# Altium schematic sheet Power.SchDoc(Power)
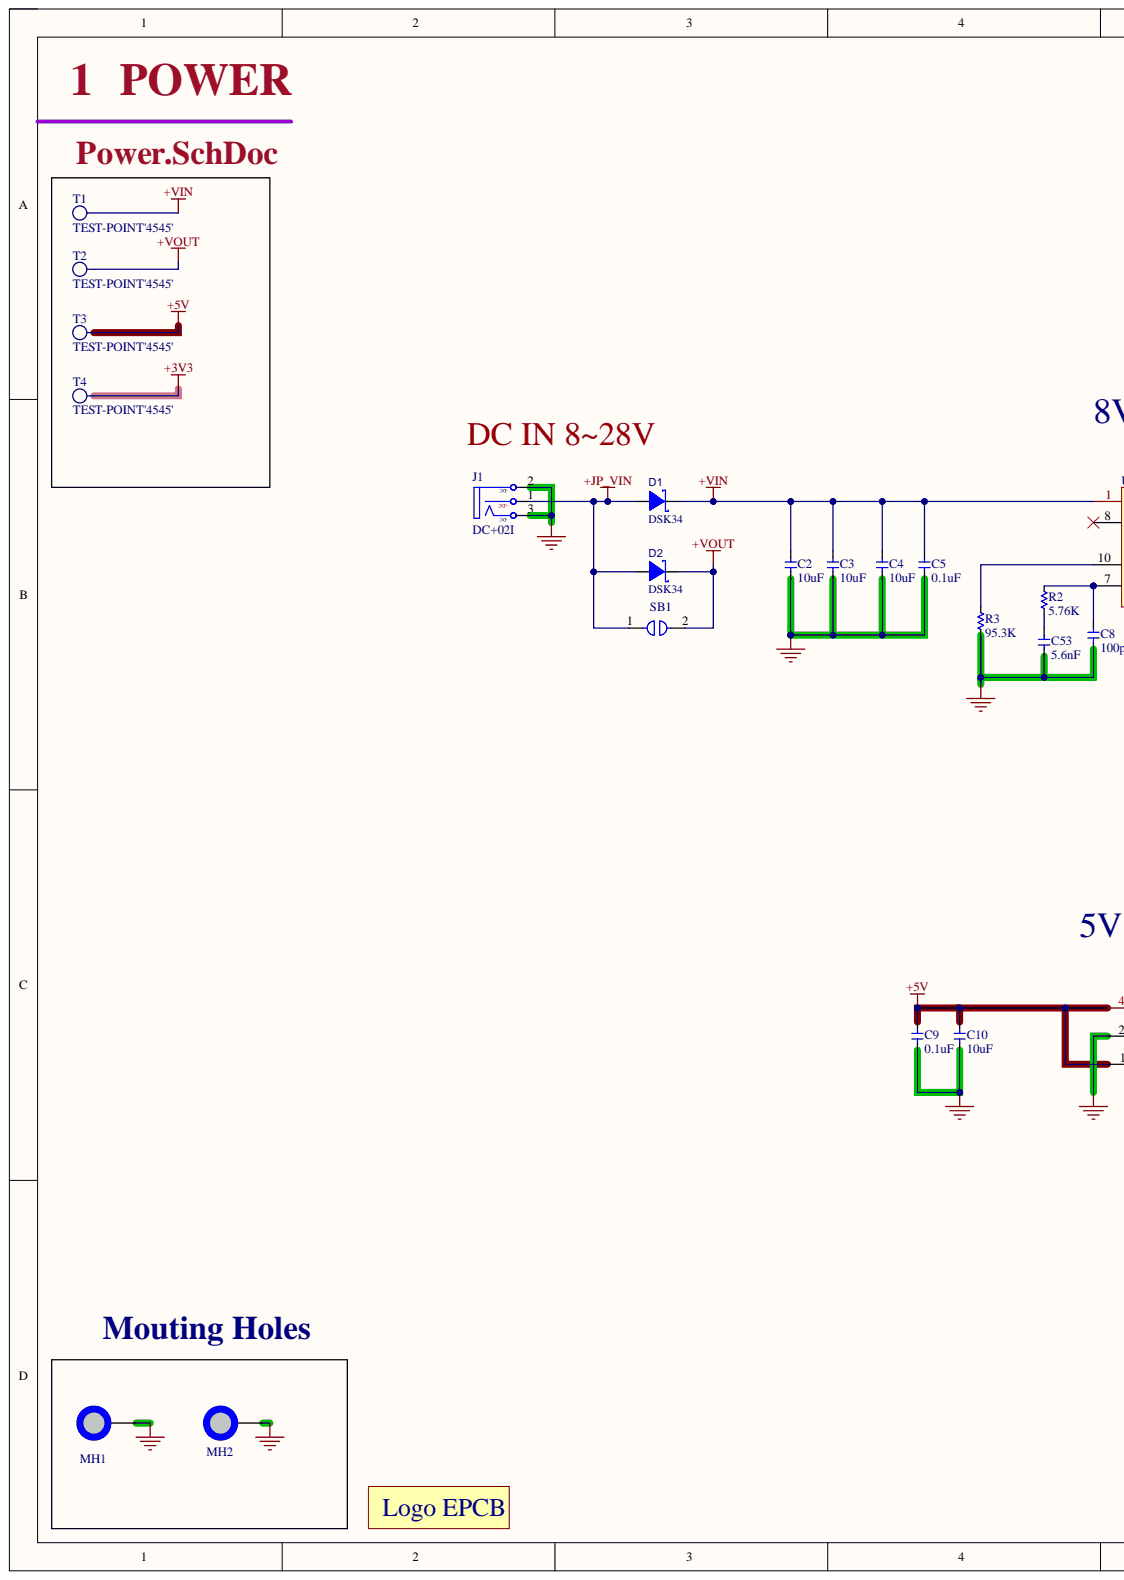
[diagram p0: Power.SchDoc, left]
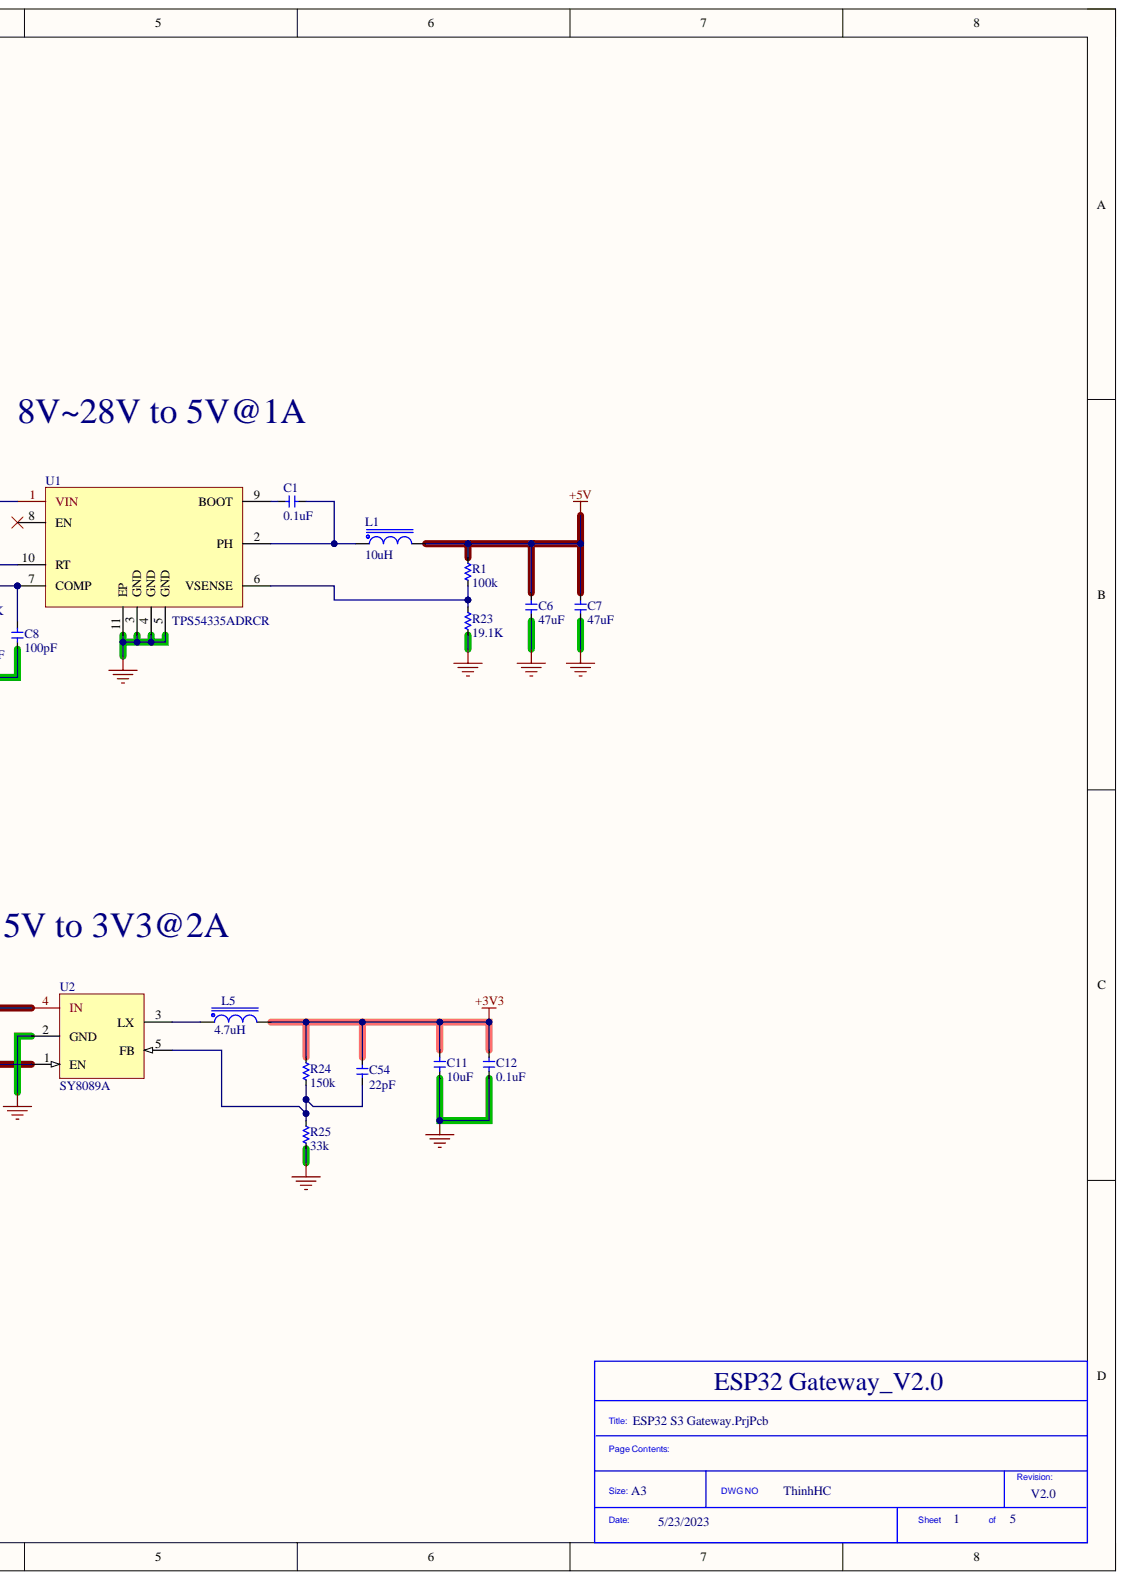
[diagram p1: Power.SchDoc, right]

## Parts
C1  0.1uF  [C_0402]
C2  10uF  [C_0805]
C3  10uF  [C_0805]
C4  10uF  [C_0805]
C5  0.1uF  [C-0603]
C6  47uF  [C-1210]
C7  47uF  [C-1210]
C8  100pF  [C_0402]
C9  0.1uF  [C_0402]
C10  10uF  [C_0603]
C11  10uF  [C_0603]
C12  0.1uF  [C_0402]
C53  5.6nF  [C_0402]
C54  22pF  [C_0402]
D1  DSK34  [SOD-123_L2.8-W1.8-LS3.7-RD]
D2  DSK34  [SOD-123_L2.8-W1.8-LS3.7-RD]
J1  DC+02I  [DC0]
L1  10uH  [IND-SMD_L4.4-W4.2]
L5  4.7uH  [IND-SMD_L4.4-W4.2]
LO1  LOGO EPCB  [LOGO EPCB]  (value not drawn)  (no BOM)
MH1  MH_6.0MMC_3.5MM  [MH_6.0MMD_3.5MM - Via]  (value not drawn)  (no BOM)
MH2  MH_6.0MMC_3.5MM  [MH_6.0MMD_3.5MM - Via]  (value not drawn)  (no BOM)
R1  100k  [R_0402]
R2  5.76K  [R_0402]
R3  95.3K  [R_0402]
R23  19.1K  [R_0402]
R24  150k  [R_0402]
R25  33k  [R_0402]
SB1  SOLDERJUMPER_1WAY_OFF  [SOLDERJUMPER_1WAY_OFF]  (value not drawn)  (no BOM)
T1  TEST-POINT'4545'  [TP-D45MIL]  (no BOM)
T2  TEST-POINT'4545'  [TP-D45MIL]  (no BOM)
T3  TEST-POINT'4545'  [TP-D45MIL]  (no BOM)
T4  TEST-POINT'4545'  [TP-D45MIL]  (no BOM)
U1  TPS54335ADRCR  [VSON-10_L3.0-W3.0-P0.50-TL-EP]
U2  SY8089A  [SOT-23-5_L3.0-W1.7-P0.95-LS2.8-BR]

## Nets
+3V3: C11.2 C12.2 C54.2 L5.2 R24.2 T4.T
+5V: C6.2 C7.2 C9.2 C10.2 L1.2 R1.2 T3.T U2.1(EN) U2.4(IN)
+JP_VIN: D1.2 D2.2 J1.1 SB1.1
+VIN: C2.2 C3.2 C4.2 C5.2 D1.1 T1.T U1.1(VIN)
+VOUT: D2.1 SB1.2 T2.T
GND: C2.1 C3.1 C4.1 C5.1 C6.1 C7.1 C8.1 C9.1 C10.1 C11.1 C12.1 C53.1 J1.2 J1.3 MH1.1 MH2.1 R3.2 R23.2 R25.2 U1.3(GND) U1.4(GND) U1.5(GND) U1.11(EP) U2.2(GND)
NetC1_1: C1.1 U1.9(BOOT)
NetC1_2: C1.2 L1.1 U1.2(PH)
NetC8_2: C8.2 R2.1 U1.7(COMP)
NetC53_2: C53.2 R2.2
NetC54_1: C54.1 R24.1 R25.1 U2.5(FB)
NetL5_1: L5.1 U2.3(LX)
NetR1_1: R1.1 R23.1 U1.6(VSENSE)
NetR3_1: R3.1 U1.10(RT)

Not connected: U1 8(EN)

## Library data of the parts
C1 C9 C12 = CL05B104KB54PNC: 50V 100nF X7R ±10% 0402 Multilayer Ceramic Capacitors MLCC - SMD/SMT ROHS; Manufacturer: Samsung Electro-Mechanics; Assembly Type: SMT Assembly; Component Kind: Standard; ComponentLink1Description: Digikey Link; ComponentLink1URL: https://www.digikey.com/en/products/detail/yageo/CC0603KRX7R9BB104/2103082?s=N4IgTCBcDaIMwEYEFoFwCzuWZA5AIiALoC%2BQA; ComponentLink2Description: LCSC Link; ComponentLink2URL: https://jlcpcb.com/parts/componentSearch?searchTxt=10k%200603; LCSC Part Number: C307331; Library Name: ESP32 S3 Gateway.SchLib; Manufacturer Part Number: CL05B104KB54PNC; Package: 0402
C2-C4 = CL21A106KBYQNNE: 50V 10uF X5R ±10% 0805 Multilayer Ceramic Capacitors MLCC - SMD/SMT ROHS; Manufacturer: Samsung Electro-Mechanics; Assembly Type: SMT Assembly; Component Kind: Standard; ComponentLink1Description: Digikey Link; ComponentLink1URL: https://www.digikey.com/en/products/detail/yageo/CC0603KRX7R9BB104/2103082?s=N4IgTCBcDaIMwEYEFoFwCzuWZA5AIiALoC%2BQA; ComponentLink2Description: LCSC Link; ComponentLink2URL: https://jlcpcb.com/parts/componentSearch?searchTxt=10k%200603; LCSC Part Number: C2932476; Library Name: ESP32 S3 Gateway.SchLib; Manufacturer Part Number: CL21A106KBYQNNE; Package: 0805
C5 = CC0603KRX7R9BB104: 50V 100nF X7R ±10% 0603 Multilayer Ceramic Capacitors MLCC - SMD/SMT ROHS; Manufacturer: YAGEO; Assembly Type: SMT Assembly; Component Kind: Standard; ComponentLink1Description: Digikey Link; ComponentLink1URL: https://www.digikey.com/en/products/detail/yageo/CC0603KRX7R9BB104/2103082?s=N4IgTCBcDaIMwEYEFoFwCzuWZA5AIiALoC%2BQA; ComponentLink2Description: LCSC Link; ComponentLink2URL: https://jlcpcb.com/parts/componentSearch?searchTxt=10k%200603; LCSC Part Number: C14663; Library Name: ESP32 S3 Gateway.SchLib; Manufacturer Part Number: CC0603KRX7R9BB104; Package: 0603
C6 C7 = GRM32ER61C476KE15L: 16V 47uF X5R ±10% 1210 Multilayer Ceramic Capacitors MLCC - SMD/SMT ROHS; Manufacturer: Murata Electronics; Assembly Type: SMT Assembly; Component Kind: Standard; ComponentLink1Description: Digikey Link; ComponentLink1URL: https://www.digikey.com/en/products/detail/yageo/CC0603KRX7R9BB104/2103082?s=N4IgTCBcDaIMwEYEFoFwCzuWZA5AIiALoC%2BQA; ComponentLink2Description: LCSC Link; ComponentLink2URL: https://jlcpcb.com/parts/componentSearch?searchTxt=10k%200603; LCSC Part Number: C77101; Library Name: ESP32 S3 Gateway.SchLib; Manufacturer Part Number: GRM32ER61C476KE15L; Package: 1210
C8 = 0402CG101J500NT: 100pF 50V C0G ±5% 0402 Multilayer Ceramic Capacitors MLCC - SMD/SMT ROHS; Manufacturer: FH(Guangdong Fenghua Advanced Tech); Assembly Type: SMT Assembly; Component Kind: Standard; ComponentLink1Description: Digikey Link; ComponentLink2Description: LCSC Link; ComponentLink2URL: https://jlcpcb.com/parts/componentSearch?searchTxt=10k%200603; LCSC Part Number: C1546; Library Name: ESP32 S3 Gateway.SchLib; Manufacturer Part Number: 0402CG101J500NT; Package: 0402
C10 C11 = 0603X106K160NT: 10uF 16V X5R ±10% 0603 Multilayer Ceramic Capacitors MLCC - SMD/SMT ROHS; Manufacturer: FH(Guangdong Fenghua Advanced Tech); Assembly Type: SMT Assembly; Component Kind: Standard; LCSC Part Number: C70225; Library Name: ESP32 S3 Gateway.SchLib; Manufacturer Part Number: 0603X106K160NT; Package: 0603
C53 = 0402B562K500NT: 5.6nF 50V X7R ±10% 0402  Multilayer Ceramic Capacitors MLCC - SMD/SMT ROHS; Manufacturer: FH(Guangdong Fenghua Advanced Tech); Assembly Type: SMT Assembly; Component Kind: Standard; ComponentLink1Description: Digikey Link; ComponentLink2Description: LCSC Link; ComponentLink2URL: https://jlcpcb.com/parts/componentSearch?searchTxt=10k%200603; LCSC Part Number: C1540; Library Name: ESP32 S3 Gateway.SchLib; Manufacturer Part Number: 0402B562K500NT; Package: 0402
C54 = 0402CG220J500NT: 50V 22pF C0G ±5% 0402 Multilayer Ceramic Capacitors MLCC - SMD/SMT ROHS; Manufacturer: FH (Guangdong Fenghua Advanced Tech); Assembly Type: SMT Assembly; Component Kind: Standard; ComponentLink1Description: Digikey Link; ComponentLink1URL: https://www.digikey.com/en/products/detail/yageo/CC0603KRX7R9BB104/2103082?s=N4IgTCBcDaIMwEYEFoFwCzuWZA5AIiALoC%2BQA; ComponentLink2Description: LCSC Link; ComponentLink2URL: https://jlcpcb.com/parts/componentSearch?searchTxt=10k%200603; LCSC Part Number: C1555; Library Name: ESP32 S3 Gateway.SchLib; Manufacturer Part Number: 0402CG220J500NT; Package: 0402
D1 D2 = DSK34: DSK34 40V 550mV@3A 3A SOD-123 Schottky Barrier Diodes (SBD) ROHS Copy; Manufacturer: MDD（Microdiode Electronics); Assembly Type: SMT Assembly; Component Kind: Standard; LCSC Part Number: C41029; Library Name: ESP32 S3 Gateway.SchLib; Manufacturer Part Number: DSK34; Package: SOD-123
J1 = DC+02I: Jac DC input 5.5x2.1MM; Manufacturer: JINJIA; Assembly Type: Hand Soldering; Component Kind: Standard; LCSC Part Number: C718033; Library Name: ESP32 Gateway 80x60.3.SCHLIB; Manufacturer Part Number: DC+02I; Package: Through Hole
L1 = IND_MWSA0402S-100MT: POWER INDUCTORS SMD,4.2X4.4X2.0MM ROHS; Manufacturer: Sunlord; Assembly Type: SMT Assembly; Component Kind: Standard; ComponentLink1Description: http://www.mouser.com/ds/2/390/CDRH5D28RHP-523509.pdf?src-supplier=Mouser; ComponentLink1URL: http://www.mouser.com/ds/2/390/CDRH5D28RHP-523509.pdf?src-supplier=Mouser; ComponentLink2Description: http://products.sumida.com/products/pdf/CDRH5D28RHP.pdf?src-supplier=Digi-Key; ComponentLink2URL: http://products.sumida.com/products/pdf/CDRH5D28RHP.pdf?src-supplier=Digi-Key; ComponentLink3Description: http://www.sumida.com/products/pdf/CDRH5D28,5D28R,5D28RHP.pdf?src-supplier=Avnet; ComponentLink3URL: http://www.sumida.com/products/pdf/CDRH5D28,5D28R,5D28RHP.pdf?src-supplier=Avnet; ComponentLink4Description: http://datasheet.octopart.com/CDRH5D28RHPNP-4R7NC-Sumida-datasheet-8324507.pdf?src-supplier=_legacy+Avnet; ComponentLink4URL: http://datasheet.octopart.com/CDRH5D28RHPNP-4R7NC-Sumida-datasheet-8324507.pdf?src-supplier=_legacy+Avnet; LCSC Part Number: C408339; Library Name: ESP32 S3 Gateway.SchLib; Manufacturer Part Number: MWSA0402S-100MT; Package: SMD-4.2x4.4x2.0
L5 = IND_MWSA0402S-4R7MT: 4.7uH IND 4.2x4.4x2.0mm 2.8A 4.7uH ±20% 105mΩ SMD Power Inductors ROHS; Manufacturer: Sunlord; Assembly Type: SMT Assembly; Component Kind: Standard; LCSC Part Number: C408337; Manufacturer Part Number: MWSA0402S-4R7MT; Package: SMD-4.2x4.4x2.0; Library Name: NH Speaker Sensor 16CH 2.2.SCHLIB
MH1 MH2 = MH_6.0MMC_3.5MM_Via: Mounting Hole 6.0MMC 3.5MM; .Creator: MM; Library Name: ESP32 S3 Gateway.SchLib
R1 = 0402WGF1003TCE: 100kΩ  ±1% 0402 Chip Resistor - Surface Mount ROHS; Manufacturer: UNI-ROYAL(Uniroyal Elec); Assembly Type: SMT Assembly; Component Kind: Standard; LCSC Part Number: C25741; Library Name: ESP32 S3 Gateway.SchLib; Manufacturer Part Number: 0402WGF1003TCE; Package: 0402
R2 = 0402WGF5761TCE: 5.76kΩ 1/16W Thick Film Resistors 50V ±1% ±100ppm/℃ -55℃~+155℃ 0402  Chip Resistor - Surface Mount ROHS; Manufacturer: Uniroyal Elec; Assembly Type: SMT Assembly; Component Kind: Standard; LCSC Part Number: C25910; Library Name: ESP32 S3 Gateway.SchLib; Manufacturer Part Number: 0402WGF5761TCE; Package: 0402
R3 = 0402WGF9532TCE: 95.3kΩ 1/16W Thick Film Resistors 50V ±1% ±100ppm/℃ -55℃~+155℃  0402  Chip Resistor - Surface Mount ROHS; Manufacturer: Uniroyal Elec; Assembly Type: SMT Assembly; Component Kind: Standard; LCSC Part Number: C26990; Library Name: ESP32 S3 Gateway.SchLib; Manufacturer Part Number: 0402WGF9532TCE; Package: 0402
R23 = 0402WGF1912TCE: 19.1kΩ 0402 1/16W Thick Film Resistors 50V ±1% ±100ppm/℃ -55℃~+155℃  Chip Resistor - Surface Mount ROHS; Manufacturer: Uniroyal Elec; Assembly Type: SMT Assembly; Component Kind: Standard; LCSC Part Number: C52036; Library Name: ESP32 S3 Gateway.SchLib; Manufacturer Part Number: 0402WGF1912TCE; Package: 0402
R24 = ERJ2RKF1503X: 150kΩ  ±1% 0402 Chip Resistor - Surface Mount ROHS; Manufacturer: PANASONIC; Assembly Type: SMT Assembly; Component Kind: Standard; LCSC Part Number: C413108; Library Name: ESP32 S3 Gateway.SchLib; Manufacturer Part Number: ERJ2RKF1503X; Package: 0402
R25 = 0402WGF3302TCE: 33kΩ ±5% 1/16W Thick Film Resistors 50V ±100ppm/℃ -55℃~+155℃  0402 Chip Resistor - Surface Mount ROHS; Manufacturer: UNI-ROYAL(Uniroyal Elec); Assembly Type: SMT Assembly; Component Kind: Standard; LCSC Part Number: C25779; Library Name: ESP32 S3 Gateway.SchLib; Manufacturer Part Number: 0402WGF3302TCE; Package: 0402
SB1 = SOLDERJUMPER_1WAY_OFF: GateName_1: G$1; Description: SOLDERJUMPER_1WAY_OFF; DeviceSetName: SOLDERJUMPER_1WAY; Library Name: ESP32 S3 Gateway.SchLib; LibraryName: microbuilder; SymbolName_1: SOLDERJUMPER_1WAY
T1-T4 = TEST-POINT'4545': Manufacturer: No; Description: TEST-POINT'4545'; LCSC Part Number: No; Library Name: ESP32 S3 Gateway.SchLib; Manufacturer Part Number: No; Package: No
U1 = TPS54335ADRCR: TPS54335A 28V 3A SOP-8_EP_150mil DC-DC Converters RoHS; Manufacturer: Texas Instruments; Assembly Type: SMT Assembly; Component Kind: Standard; ComponentLink1Description: http://www.jameco.com/Jameco/Products/ProdDS/1523997.pdf?src-supplier=Jameco; ComponentLink1URL: http://www.jameco.com/Jameco/Products/ProdDS/1523997.pdf?src-supplier=Jameco; ComponentLink2Description: http://www.ti.com/general/docs/lit/getliterature.tsp?genericPartNumber=TPS5431&&fileType=pdf&src-supplier=Avnet; ComponentLink2URL: http://www.ti.com/general/docs/lit/getliterature.tsp?genericPartNumber=TPS5431&&fileType=pdf&src-supplier=Avnet; ComponentLink3Description: http://focus.ti.com/lit/ds/symlink/tps5430.pdf?src-supplier=Avnet+Europe; ComponentLink3URL: http://focus.ti.com/lit/ds/symlink/tps5430.pdf?src-supplier=Avnet+Europe; ComponentLink4Description: http://www.ti.com/lit/ds/symlink/tps5431.pdf?src-supplier=Digi-Key; ComponentLink4URL: http://www.ti.com/lit/ds/symlink/tps5431.pdf?src-supplier=Digi-Key; ComponentLink5Description: https://www.verical.com/server-webapp/html/getDatasheet?partID=214658&src-supplier=Verical; ComponentLink5URL: https://www.verical.com/server-webapp/html/getDatasheet?partID=214658&src-supplier=Verical; ComponentLink6Description: http://www.produktinfo.conrad.com/datenblaetter/1000000-1099999/001014839-da-01-en-IC_REG_BUCK_ADJ_3_TPS5430DDAR_SOIC_8_TID.pdf?src-supplier=Conrad; ComponentLink6URL: http://www.produktinfo.conrad.com/datenblaetter/1000000-1099999/001014839-da-01-en-IC_REG_BUCK_ADJ_3_TPS5430DDAR_SOIC_8_TID.pdf?src-supplier=Conrad; LCSC Part Number: C473400; Library Name: ESP32 Gateway 80x60.3.SchLib; Manufacturer Part Number: TPS54335ADRCR; Package: VSON-10
U2 = SY8089A1AAC: High Efficiency 5.5V, 2A continuous, 3A peak, 1MHz  Synchronous Step Down Regulator; Manufacturer: Silergy Corp; Assembly Type: SMT Assembly; Component Kind: Standard; LCSC Part Number: C479074; Manufacturer Part Number: SY8089A1AAC; Package: SOT-23-5; Library Name: NH Speaker Sensor 16CH 2.2.SCHLIB
LO1 = LOGO EPCB: Description: LOGO EPCB; Library Name: ESP32 S3 Gateway.SchLib
